# Altium schematic sheet IOT_Meteo_sensor.SchDoc(IOT_Meteo_sensor)
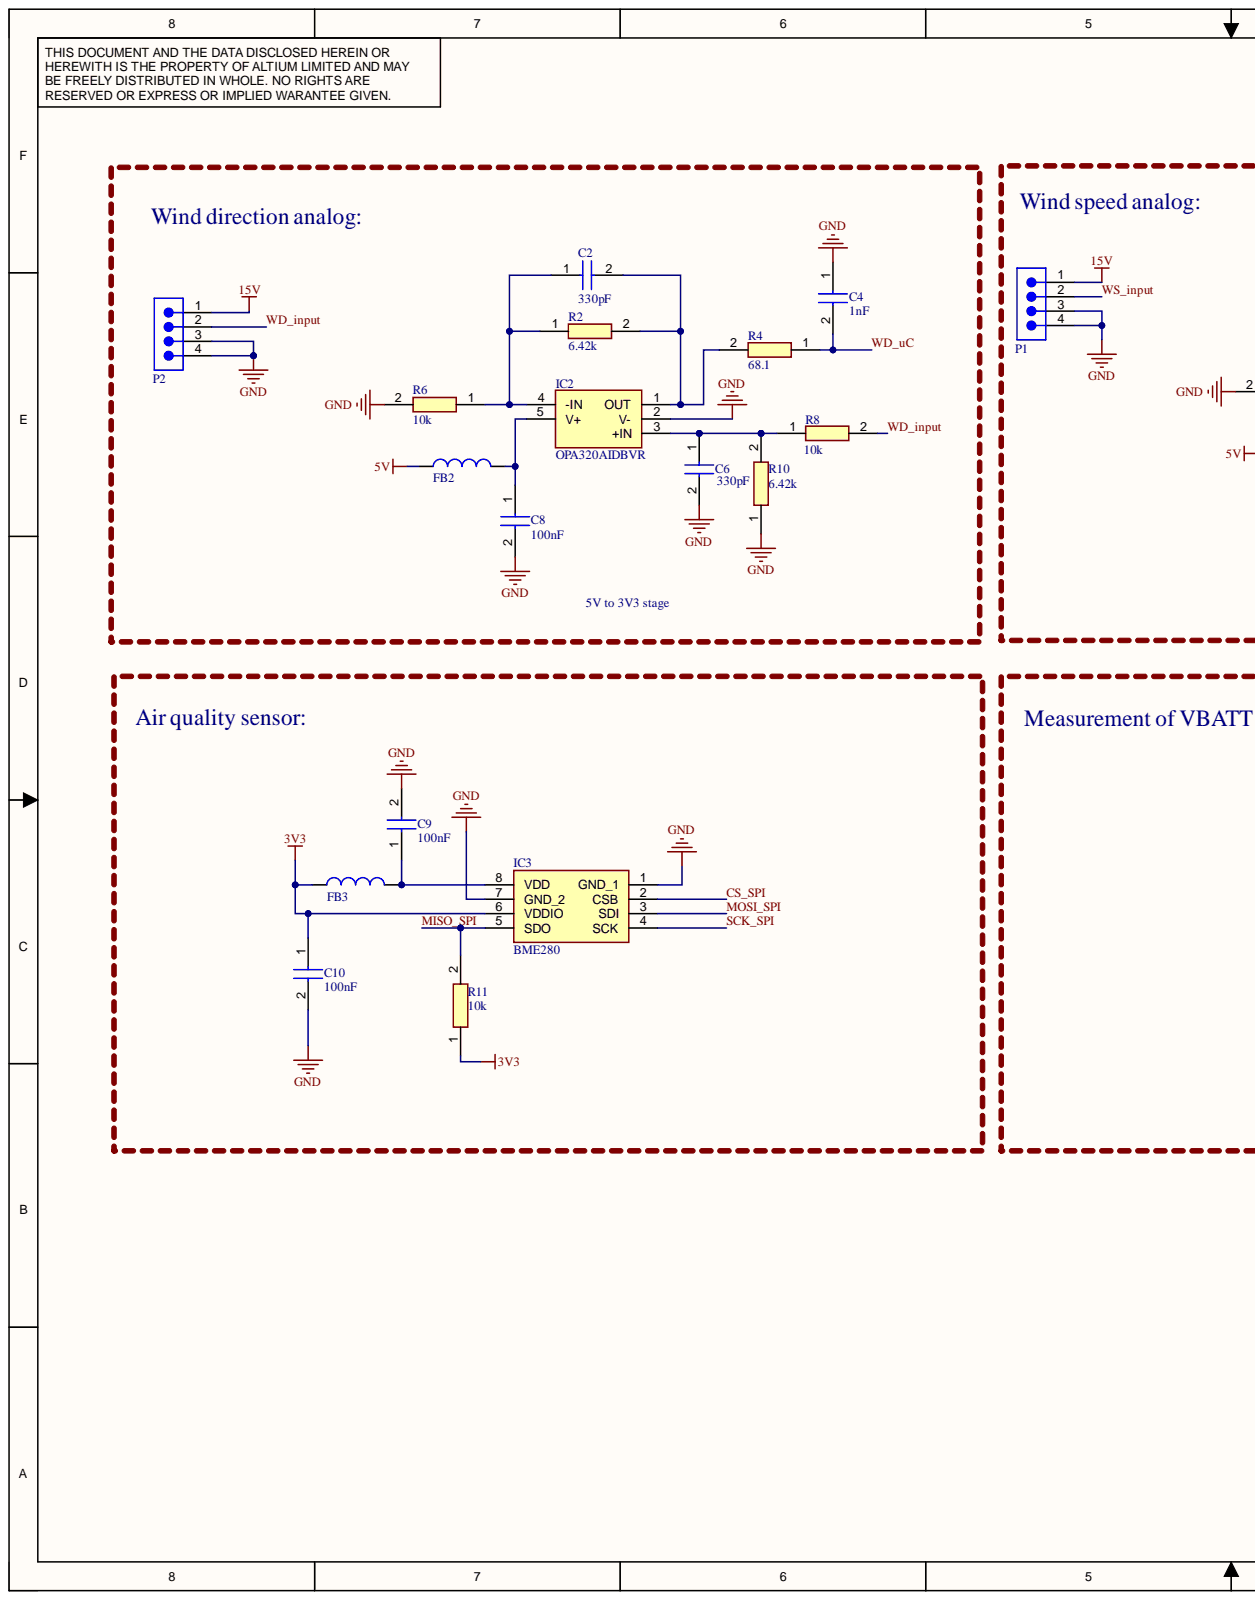
[diagram p0: IOT_Meteo_sensor.SchDoc, left]
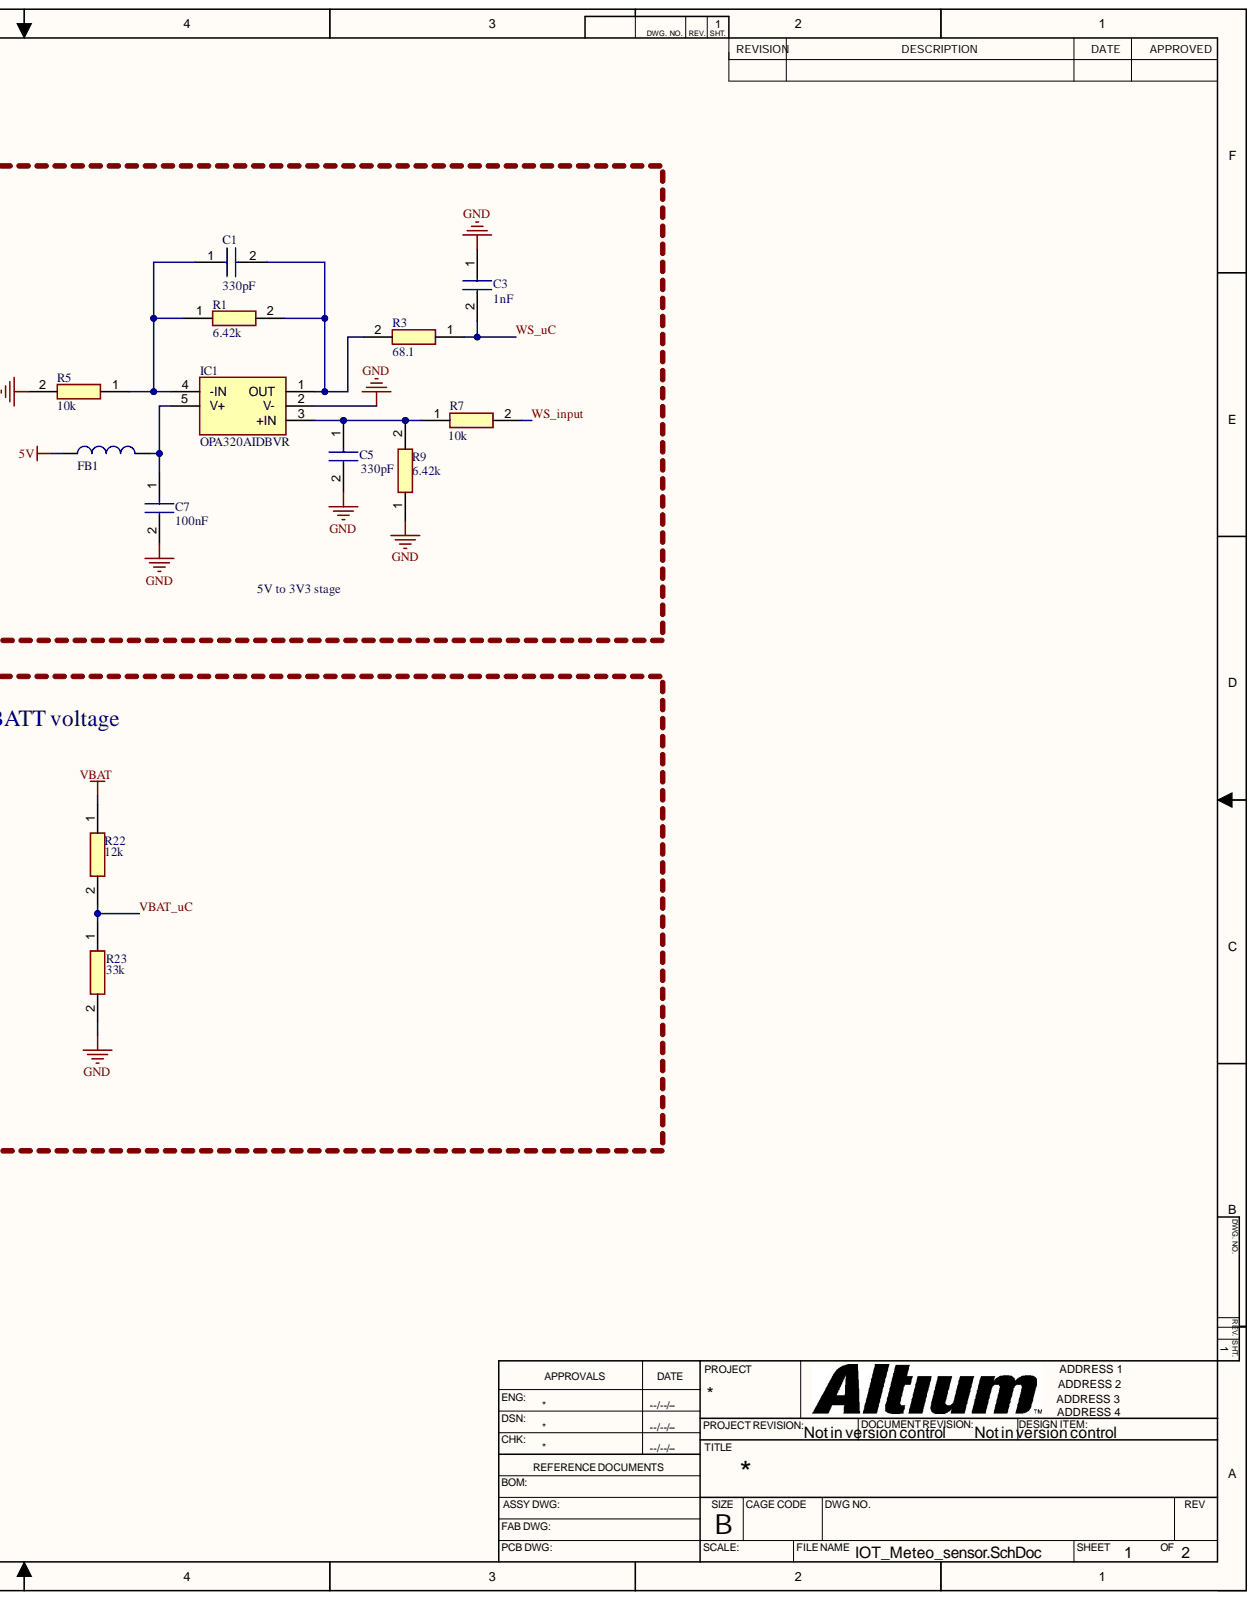
[diagram p1: IOT_Meteo_sensor.SchDoc, right]

## Parts
C1  value 330pF  [CAP0603]  (hidden comment: 06035A331JAT2A)
C2  value 330pF  [CAP0603]  (hidden comment: 06035A331JAT2A)
C3  value 1nF  [CAP0603]  (hidden comment: GRM188R72E102KW07D)
C4  value 1nF  [CAP0603]  (hidden comment: GRM188R72E102KW07D)
C5  value 330pF  [CAP0603]  (hidden comment: 06035A331JAT2A)
C6  value 330pF  [CAP0603]  (hidden comment: 06035A331JAT2A)
C7  value 100nF  [CAP0603]  (hidden comment: CL10B104KO8NNNC)
C8  value 100nF  [CAP0603]  (hidden comment: CL10B104KO8NNNC)
C9  value 100nF  [CAP0603]  (hidden comment: CL10B104KO8NNNC)
C10  value 100nF  [CAP0603]  (hidden comment: CL10B104KO8NNNC)
FB1  26Ohm  [WE-MPSB_0603_L1.6W0.8H0.8]  (value not drawn)
FB2  26Ohm  [WE-MPSB_0603_L1.6W0.8H0.8]  (value not drawn)
FB3  26Ohm  [WE-MPSB_0603_L1.6W0.8H0.8]  (value not drawn)
IC1  OPA320AIDBVR  [SOT95P280X145-5N]
IC2  OPA320AIDBVR  [SOT95P280X145-5N]
IC3  BME280  [BME280]
P1  691382010004  [691382010004]  (value not drawn)
P2  691382010004  [691382010004]  (value not drawn)
R1  value 6.42k  [RESC0603]  (hidden comment: RT0603BRD076K42L)
R2  value 6.42k  [RESC0603]  (hidden comment: RT0603BRD076K42L)
R3  value 68.1  [RESC0603]  (hidden comment: CRCW060368R1FKEA)
R4  value 68.1  [RESC0603]  (hidden comment: CRCW060368R1FKEA)
R5  value 10k  [RESC0603]  (hidden comment: TNPW060310K0BEEA)
R6  value 10k  [RESC0603]  (hidden comment: TNPW060310K0BEEA)
R7  value 10k  [RESC0603]  (hidden comment: TNPW060310K0BEEA)
R8  value 10k  [RESC0603]  (hidden comment: TNPW060310K0BEEA)
R9  value 6.42k  [RESC0603]  (hidden comment: RT0603BRD076K42L)
R10  value 6.42k  [RESC0603]  (hidden comment: RT0603BRD076K42L)
R11  value 10k  [RESC0603]  (hidden comment: CRCW060310K0JNEC)
R22  value 12k  [RESC0603]  (hidden comment: ERA-3ARB123V)
R23  value 33k  [RESC0603]  (hidden comment: ERA-3AEB333V)

## Nets
3V3: C10.1 FB3.2 IC3.6(VDDIO) R11.1
5V: FB1.2 FB2.2
15V: P1.1 P2.1
GND: C3.1 C4.1 C5.2 C6.2 C7.2 C8.2 C9.2 C10.2 IC1.2(V-) IC2.2(V-) IC3.1(GND_1) IC3.7(GND_2) P1.3 P1.4 P2.3 P2.4 R5.2 R6.2 R9.1 R10.1 R23.2
VBAT: R22.1
CS_SPI: IC3.2(CSB)
MISO_SPI: IC3.5(SDO) R11.2
MOSI_SPI: IC3.3(SDI)
SCK_SPI: IC3.4(SCK)
VBAT_uC: R22.2 R23.1
WD_input: P2.2 R8.2
WD_uC: C4.2 R4.1
WS_input: P1.2 R7.2
WS_uC: C3.2 R3.1
NetC1_1: C1.1 IC1.4(-IN) R1.1 R5.1
NetC1_2: C1.2 IC1.1(OUT) R1.2 R3.2
NetC2_1: C2.1 IC2.4(-IN) R2.1 R6.1
NetC2_2: C2.2 IC2.1(OUT) R2.2 R4.2
NetC5_1: C5.1 IC1.3(+IN) R7.1 R9.2
NetC6_1: C6.1 IC2.3(+IN) R8.1 R10.2
NetC7_1: C7.1 FB1.1 IC1.5(V+)
NetC8_1: C8.1 FB2.1 IC2.5(V+)
NetC9_1: C9.1 FB3.1 IC3.8(VDD)

## Library data of the parts
C1 C2 C5 C6 = 06035A331JAT2A: Multilayer Ceramic Capacitors MLCC - SMD/SMT  50V 330pF; Manufacturer_Name: KYOCERA AVX; Case: 0603; Component Kind: Standard; Datasheet Link: https://product.tdk.com/info/en/catalog/datasheets/mlcc_commercial_midvoltage_en.pdf; Height: 0.8mm; Library Name: Library_JS.IntLib; Manufacturer_Part_Number: 06035A331JAT2A
C3 C4 = GRM188R72E102KW07D: Multilayer Ceramic Capacitors MLCC - SMD/SMT 1000 pF 250 VDC 10% 0603 X7R; Manufacturer_Name: Murata Electronics; Case: 0603; Component Kind: Standard; Datasheet Link: https://product.tdk.com/info/en/catalog/datasheets/mlcc_commercial_midvoltage_en.pdf; Height: 0.8mm; Library Name: Library_JS.IntLib; Manufacturer_Part_Number: GRM188R72E102KW07D
C7-C10 = CL10B104KO8NNNC: Multilayer Ceramic Capacitors MLCC - SMD/SMT 100nF+/-10% 16V X7R 1608; Manufacturer_Name: Samsung Electro-Mechanics; Case: 0603; Component Kind: Standard; Datasheet Link: https://product.tdk.com/info/en/catalog/datasheets/mlcc_commercial_midvoltage_en.pdf; Height: 0.8mm; Library Name: Library_JS.IntLib; Manufacturer_Part_Number: CL10B104KO8NNNC
FB1-FB3 = 74279228260: WE-MPSB EMI Multilayer Power Suppression Bead, size 0603, 26Ohm, 6.5A; Manufacturer: Wurth Elektronik; Case/Size Code: 0603; DC Resistance: 5mOhm; Impedance @1GHz: 33Ohm; Impedance Max: 39Ohm; Impedance Max Test Condition: 515MHz; Type: High Current; Category: Ferrites for PCB Assembly; Component Kind: Standard; ComponentLink1Description: Website Link; ComponentLink1URL: https://www.we-online.com/redexpert/article/74279228260?ad; ComponentLink2Description: Datasheet Link; ComponentLink2URL: https://www.we-online.com/redexpert/spec/74279228260?ad; Height: 0.8mm; Impedance @100MHz: 26Ohm; Length: 1.6mm; Library Name: WE-MPSB (rev22c).IntLib; Manufacturer Part Number: 74279228260; Match Code: WE-MPSB; Mount: Surface Mount; Operating Temperature Max: 125°C; Operating Temperature Min: -55°C; Packaging: Tape and Reel; Rated Current: 6.5A; Simulation: 0603_74279228260_26ohm; Width: 0.8mm
IC1 IC2 = OPA320AIDBVR: Precision Amplifiers PRECISION,20MHZ,RRIO CMOS OP AMP; Manufacturer_Name: Texas Instruments; Component Kind: Standard; Datasheet Link: http://www.ti.com/lit/gpn/opa320; Height: 1.45mm; Library Name: Library_JS.IntLib; Manufacturer_Part_Number: OPA320AIDBVR
IC3 = BME280: Air Quality Sensors MEMS humidity, pressure and temperature sensor; Manufacturer_Name: BOSCH - PROFESSIONAL (BLUE); Component Kind: Standard; Datasheet Link: https://www.bosch-sensortec.com/media/boschsensortec/downloads/datasheets/bst-bme280-ds002.pdf; Height: 1mm; Library Name: Library_JS.IntLib; Manufacturer_Part_Number: BME280
P1 P2 = 691382010004: WR-TBL Series 382 Horizontal PCB Header, THT, pitch 2.5mm, 4p; Manufacturer: Wurth Elektronik; Modularity: No; Rated Current: 4A; Working Voltage: 160V; Application: Pluggable; Category: Terminal Blocks; Component Kind: Standard; ComponentLink1Description: Website Link; ComponentLink1URL: https://www.we-online.com/redexpert/article/691382010004?ad; ComponentLink2Description: Datasheet Link; ComponentLink2URL: https://www.we-online.com/redexpert/spec/691382010004?ad; Library Name: Altium_WR-TBL (rev23f).IntLib; Manufacturer Part Number: 691382010004; Match Code: WR-TBL; Mount: Through Hole; Number of Pins: 4; Number of Rows: 1; Operating Temperature Max: 105°C; Operating Temperature Min: -40°C; Orientation: Horizontal; Packaging: Box; Pitch: 2.5mm
R1 R2 R9 R10 = RT0603BRD076K42L: Thin Film Resistors - SMD 1/10W 6.42K Ohms .1%; Manufacturer_Name: YAGEO; Case: 0603; Component Kind: Standard; Height: 0.55mm; Library Name: Library_JS.IntLib; Manufacturer_Part_Number: RT0603BRD076K42L
R3 R4 = CRCW060368R1FKEA: Thick Film Resistors - SMD 1/10watt 68.1ohms 1%; Manufacturer_Name: Vishay / Dale; Case: 0603; Component Kind: Standard; Height: 0.55mm; Library Name: Library_JS.IntLib; Manufacturer_Part_Number: CRCW060368R1FKEA
R5-R8 = TNPW060310K0BEEA: Thin Film Resistors - SMD 10Kohms .1% 25ppm; Manufacturer_Name: Vishay / Dale; Case: 0603; Component Kind: Standard; Height: 0.55mm; Library Name: Library_JS.IntLib; Manufacturer_Part_Number: TNPW060310K0BEEA
R11 = CRCW060310K0JNEC: Thick Film Resistors - SMD 10K OHM 5%; Manufacturer_Name: Vishay / Dale; Case: 0603; Component Kind: Standard; Height: 0.55mm; Library Name: Library_JS.IntLib; Manufacturer_Part_Number: CRCW060310K0JNEC
R22 = ERA-3ARB123V: Thin Film Resistors 0603 12Kohm 0.1% 10ppm AEC-Q200; Manufacturer_Name: Panasonic; Case: 0603; Component Kind: Standard; Height: 0.55mm; Library Name: Library_JS.IntLib; Manufacturer_Part_Number: ERA-3ARB123V
R23 = ERA-3AEB333V: Thin Film Resistors 0603 1/10W 33Kohms 0.1%; Manufacturer_Name: Panasonic; Case: 0603; Component Kind: Standard; Height: 0.55mm; Library Name: Library_JS.IntLib; Manufacturer_Part_Number: ERA-3AEB333V
